# Altium schematic sheet Sheet2.SchDoc(Sheet2)
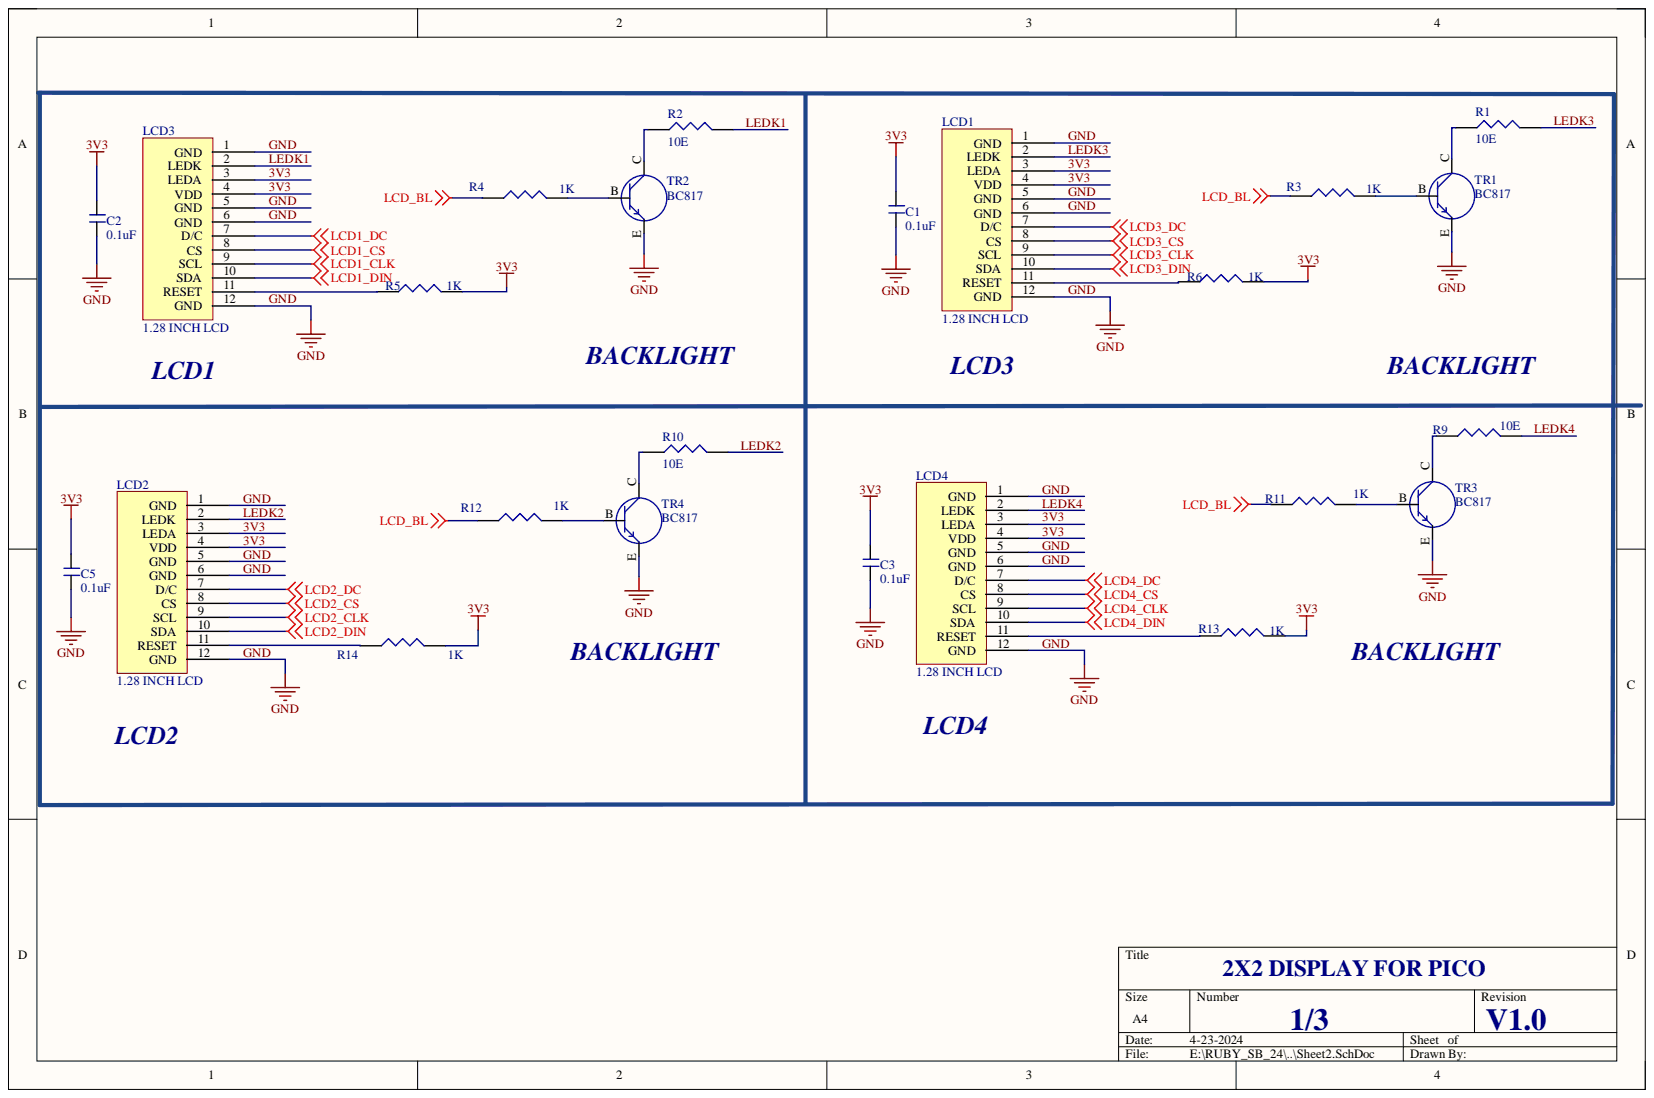
[diagram p0: Sheet2.SchDoc]

## Parts
C1  0.1uF  [C_0603]
C2  0.1uF  [C_0603]
C3  0.1uF  [C_0603]
C5  0.1uF  [C_0603]
LCD1  1.28 INCH LCD  [1.28 ROUND NON TOUCH(WITHOUT SILK)]
LCD2  1.28 INCH LCD  [1.28 ROUND NON TOUCH(WITHOUT SILK)]
LCD3  1.28 INCH LCD  [1.28 ROUND NON TOUCH(WITHOUT SILK)]
LCD4  1.28 INCH LCD  [1.28 ROUND NON TOUCH(WITHOUT SILK)]
R1  10E  [R_0603]
R2  10E  [R_0603]
R3  1K  [R_0603]
R4  1K  [R_0603]
R5  1K  [R_0603]
R6  1K  [R_0603]
R9  10E  [R_0603]
R10  10E  [R_0603]
R11  1K  [R_0603]
R12  1K  [R_0603]
R13  1K  [R_0603]
R14  1K  [R_0603]
TR1  BC817  [SOT23]
TR2  BC817  [SOT23]
TR3  BC817  [SOT23]
TR4  BC817  [SOT23]

## Nets
LCD1_CLK: LCD3.9(SCL)
LCD1_CS: LCD3.8(CS)
LCD1_DC: LCD3.7(D/C)
LCD1_DIN: LCD3.10(SDA)
LCD2_CLK: LCD2.9(SCL)
LCD2_CS: LCD2.8(CS)
LCD2_DC: LCD2.7(D/C)
LCD2_DIN: LCD2.10(SDA)
LCD3_CLK: LCD1.9(SCL)
LCD3_CS: LCD1.8(CS)
LCD3_DC: LCD1.7(D/C)
LCD3_DIN: LCD1.10(SDA)
LCD4_CLK: LCD4.9(SCL)
LCD4_CS: LCD4.8(CS)
LCD4_DC: LCD4.7(D/C)
LCD4_DIN: LCD4.10(SDA)
LCD_BL: R3.1 R4.1 R11.1 R12.1
3V3: C1.2 C2.2 C3.2 C5.2 LCD1.3(LEDA) LCD1.4(VDD) LCD2.3(LEDA) LCD2.4(VDD) LCD3.3(LEDA) LCD3.4(VDD) LCD4.3(LEDA) LCD4.4(VDD) R5.2 R6.2 R13.2 R14.2
GND: C1.1 C2.1 C3.1 C5.1 LCD1.1(GND) LCD1.5(GND) LCD1.6(GND) LCD1.12(GND) LCD2.1(GND) LCD2.5(GND) LCD2.6(GND) LCD2.12(GND) LCD3.1(GND) LCD3.5(GND) LCD3.6(GND) LCD3.12(GND) LCD4.1(GND) LCD4.5(GND) LCD4.6(GND) LCD4.12(GND) TR1.E TR2.E TR3.E TR4.E
LEDK1: LCD3.2(LEDK) R2.2
LEDK2: LCD2.2(LEDK) R10.2
LEDK3: LCD1.2(LEDK) R1.2
LEDK4: LCD4.2(LEDK) R9.2
NetLCD1_11: LCD1.11(RESET) R6.1
NetLCD2_11: LCD2.11(RESET) R14.1
NetLCD3_11: LCD3.11(RESET) R5.1
NetLCD4_11: LCD4.11(RESET) R13.1
NetR1_1: R1.1 TR1.C
NetR2_1: R2.1 TR2.C
NetR3_2: R3.2 TR1.B
NetR4_2: R4.2 TR2.B
NetR9_1: R9.1 TR3.C
NetR10_1: R10.1 TR4.C
NetR11_2: R11.2 TR3.B
NetR12_2: R12.2 TR4.B

## Library data of the parts
C1-C3 C5 = CAP_NONPOLAR: Component Kind: Standard; Library Name: dxp_pasl_lib.IntLib
LCD1-LCD4 = LCD ROUND: 1.28 INCH ROUND LCD GC9A01; Component Kind: Standard; Library Name: dxp_pasl_lib.IntLib
R1-R6 R9-R14 = RES: Component Kind: Standard; Library Name: dxp_pasl_lib.IntLib
TR1-TR4 = TRANSISTER_NPN: Component Kind: Standard; Library Name: dxp_pasl_lib.IntLib
